# Altium schematic sheet mcu.SchDoc
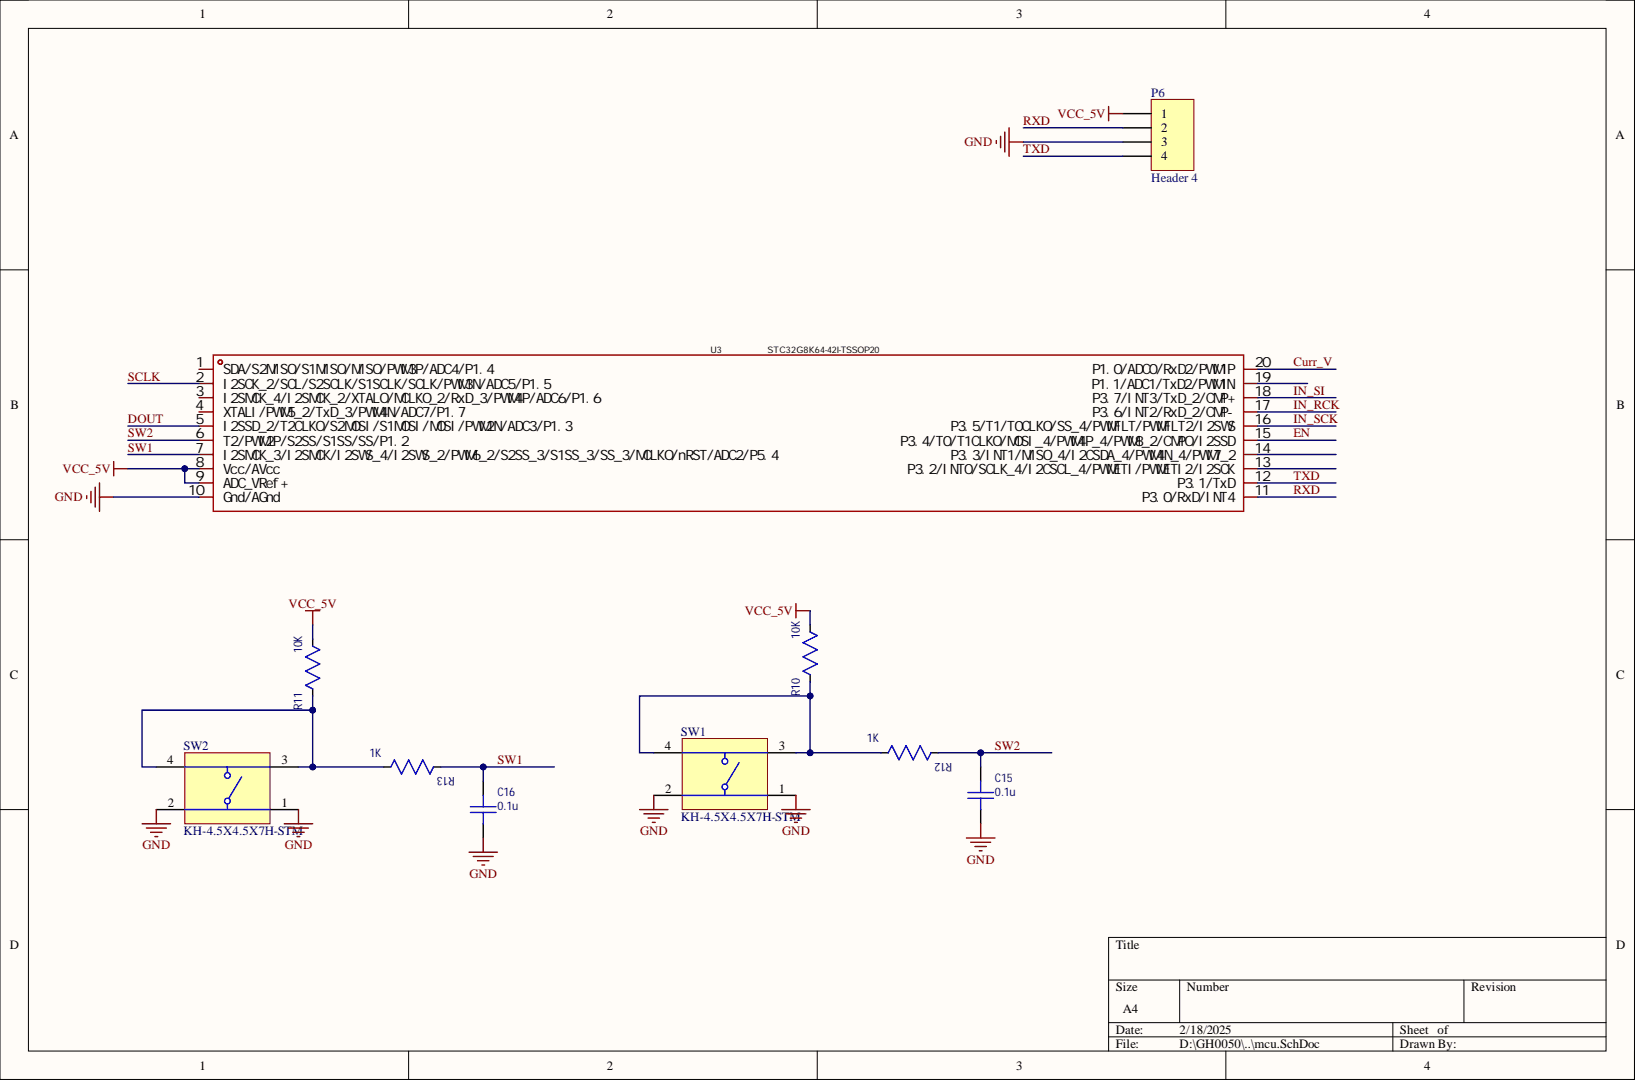
[diagram p0: mcu.SchDoc]

## Parts
C15  value 0.1u  [CAPC1608X092N_0603]  (hidden comment: Capacitor_0603)
C16  value 0.1u  [CAPC1608X092N_0603]  (hidden comment: Capacitor_0603)
P6  Header 4  [1.27-4P]  (no BOM)
R10  10K  [RESC1608X055N_0603]
R11  10K  [RESC1608X055N_0603]
R12  value 1K  [RESC1608X055N_0603]  (hidden comment: 1K/1%)
R13  value 1K  [RESC1608X055N_0603]  (hidden comment: 1K/1%)
SW1  KH-4.5X4.5X7H-STM  [SW-SMD_4P-L4.5-W4.5-P3.00-LS6.8]
SW2  KH-4.5X4.5X7H-STM  [SW-SMD_4P-L4.5-W4.5-P3.00-LS6.8]
U3  STC32G8K64-42I-TSSOP20  [TSSOP-20_L6.5-W4.4-P0.65-LS6.4-BL]

## Nets
GND: C15.2 C16.2 P6.3 SW1.1 SW1.2 SW2.1 SW2.2 U3.10(Gnd/AGnd)
VCC_5V: P6.1 R10.1 R11.1 U3.8(Vcc/AVcc) U3.9(ADC_VRef+)
Curr_V: U3.20(P1.0/ADC0/RxD2/PWM1P)
DOUT: U3.5(I2SSD_2/T2CLKO/S2MOSI/S1MOSI/MOSI/PWM2N/ADC3/P1.3)
EN: U3.15(P3.4/T0/T1CLKO/MOSI_4/PWM4P_4/PWM8_2/CMPO/I2SSD)
IN_RCK: U3.17(P3.6/INT2/RxD_2/CMP-)
IN_SCK: U3.16(P3.5/T1/T0CLKO/SS_4/PWMFLT/PWMFLT2/I2SWS)
IN_SI: U3.18(P3.7/INT3/TxD_2/CMP+)
RXD: P6.2 U3.11(P3.0/RxD/INT4)
SCLK: U3.2(I2SCK_2/SCL/S2SCLK/S1SCLK/SCLK/PWM3N/ADC5/P1.5)
SW1: C16.1 R13.2 U3.7(I2SMCK_3/I2SMCK/I2SWS_4/I2SWS_2/PWM6_2/S2SS_3/S1SS_3/SS_3/MCLKO/nRST/ADC2/P5.4)
SW2: C15.1 R12.2 U3.6(T2/PWM2P/S2SS/S1SS/SS/P1.2)
TXD: P6.4 U3.12(P3.1/TxD)
NetR10_2: R10.2 R12.1 SW1.3 SW1.4
NetR11_2: R11.2 R13.1 SW2.3 SW2.4

Not connected: U3 1(SDA/S2MISO/S1MISO/MISO/PWM3P/ADC4/P1.4) 3(I2SMCK_4/I2SMCK_2/XTALO/MCLKO_2/RxD_3/PWM4P/ADC6/P1.6) 4(XTALI/PWM5_2/TxD_3/PWM4N/ADC7/P1.7) 13(P3.2/INT0/SCLK_4/I2CSCL_4/PWMETI/PWMETI2/I2SCK) 14(P3.3/INT1/MISO_4/I2CSDA_4/PWM4N_4/PWM7_2) 19(P1.1/ADC1/TxD2/PWM1N)

## Library data of the parts
C15 C16 = Capacitor_0603: Capacitor, MLCC; Manufacturer: KEMET; PartNumber: C0603C104M5RACTU; TempCo: [tempco]; Tolerance: [tol]; Voltage Rating: [voltage]; Component Kind: Standard; ComponentLink1Description: Datasheet; Package: 0603; SupplierDescription: CAP CER 0.1UF 50V X7R 0603; Library Name: Karl.SchLib
R10-R13 = Resistor_0603: Resistor, Standard; Manufacturer: Yageo; PartNumber: RC0603FR-1075KL; Power Rating: [power]; TempCo: [tempco]; Tolerance: tol; Component Kind: Standard; ComponentLink1Description: Datasheet; Package: 0603; SupplierDescription: RES SMD 75K OHM 1% 1/10W 0603; Library Name: Karl.SchLib
  R10 R11: Value: 10K
SW1 SW2 = J1L0503110: Tactile Switch, 6 × 6 mm, Height 5.0mm, 4-Pin THD; Component Kind: Standard; Max Operating Temperature: 70 degC; Min Operating Temperature: -25 degC; PartNumber: J1L0503110; Library Name: Bogen.SchLib
U3 = STC32G8K64-42I-TSSOP20: Manufacturer: STC; Add into BOM: yes; Component Kind: Standard; Convert to PCB: yes; Datasheet: https://atta.szlcsc.com/upload/public/pdf/source/20241226/E8981118341CB9C62D3D58EFD7554832.pdf; Device: STC32G8K64-42I-TSSOP20; JLCPCB Part Class: Extended Part; LCSC Part Name: STC32G8K64-42I-TSSOP20; Manufacturer Part: STC32G8K64-42I-TSSOP20; PCB3D: TSSOP-20_L6.5-W4.4-H1.0-LS6.4-P0.65; Supplier: LCSC; Supplier Footprint: TSSOP-20; Supplier Part: C20611528; Symbol: STC32G8K64-42I-TSSOP20
P6 = Header 4: Library Name: Miscellaneous Connectors.IntLib; Signal Integrity: Connector; Publisher: Altium Limited
